# Altium schematic sheet Mirny.schdoc
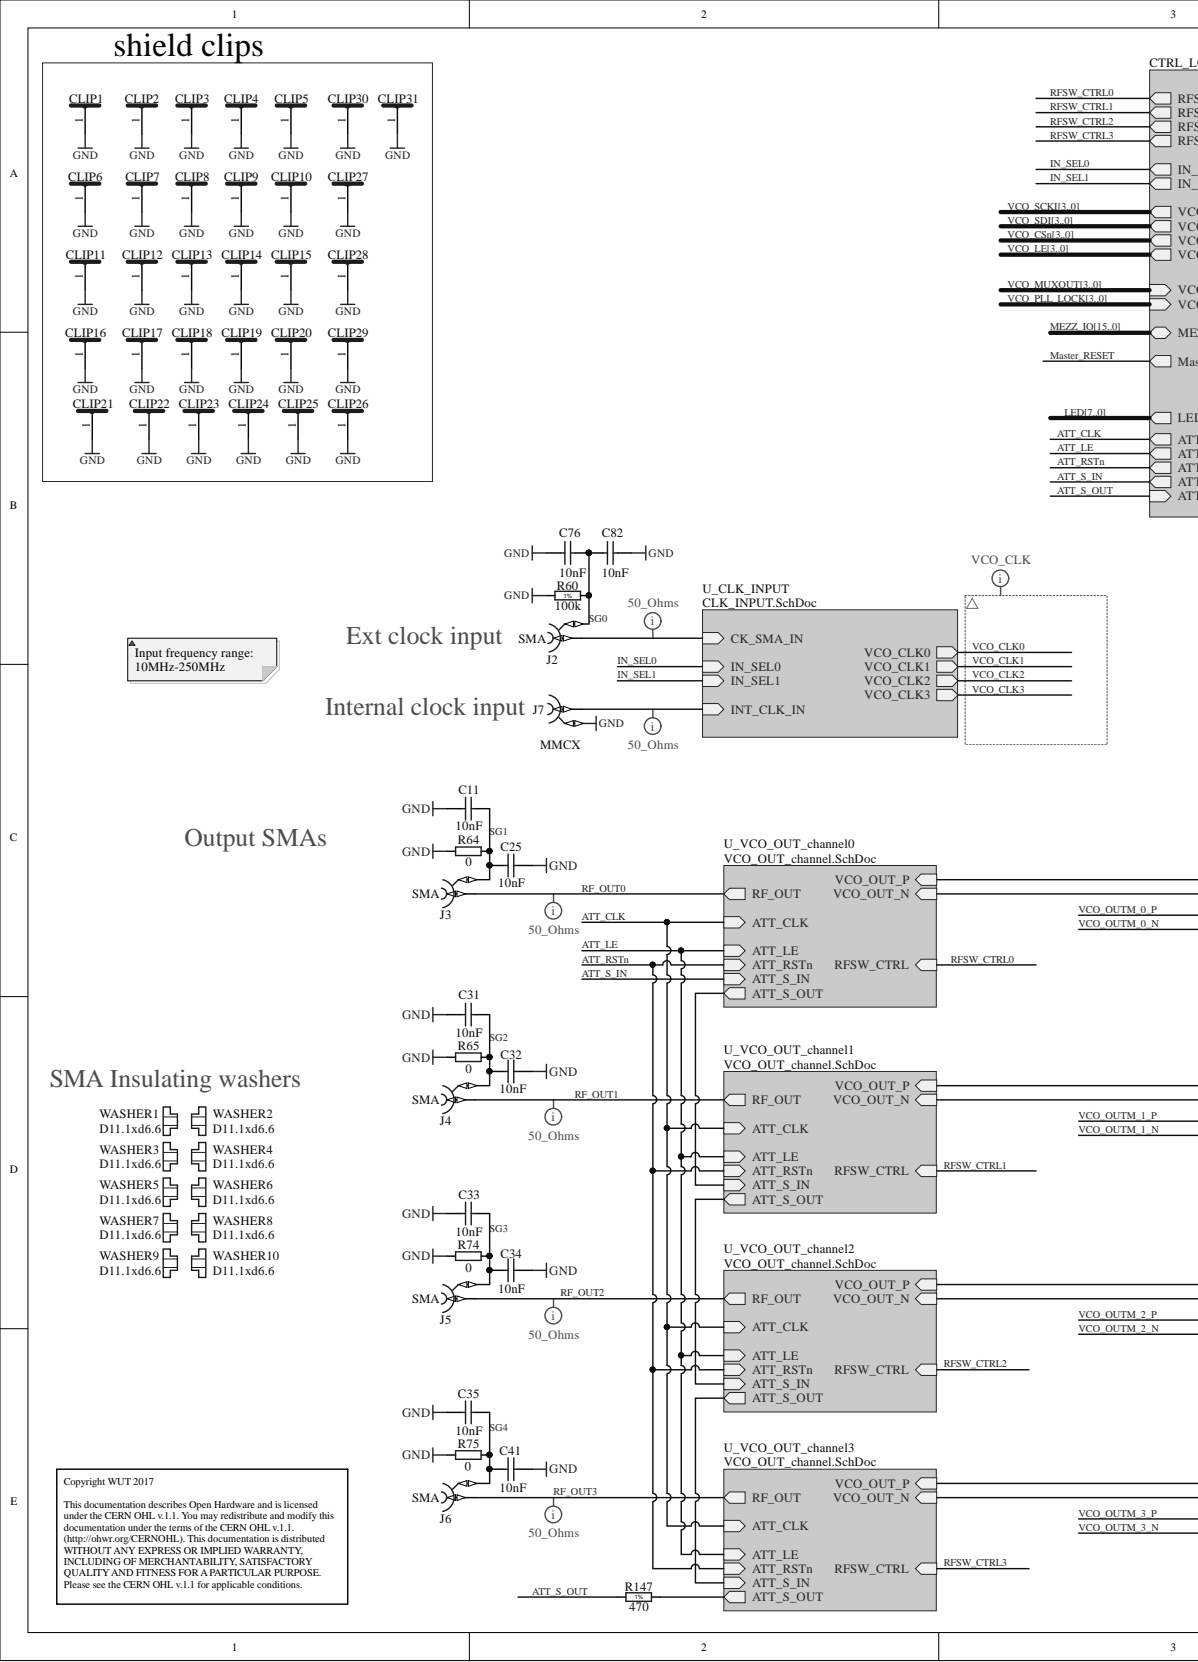
[diagram p0: Mirny.schdoc, left]
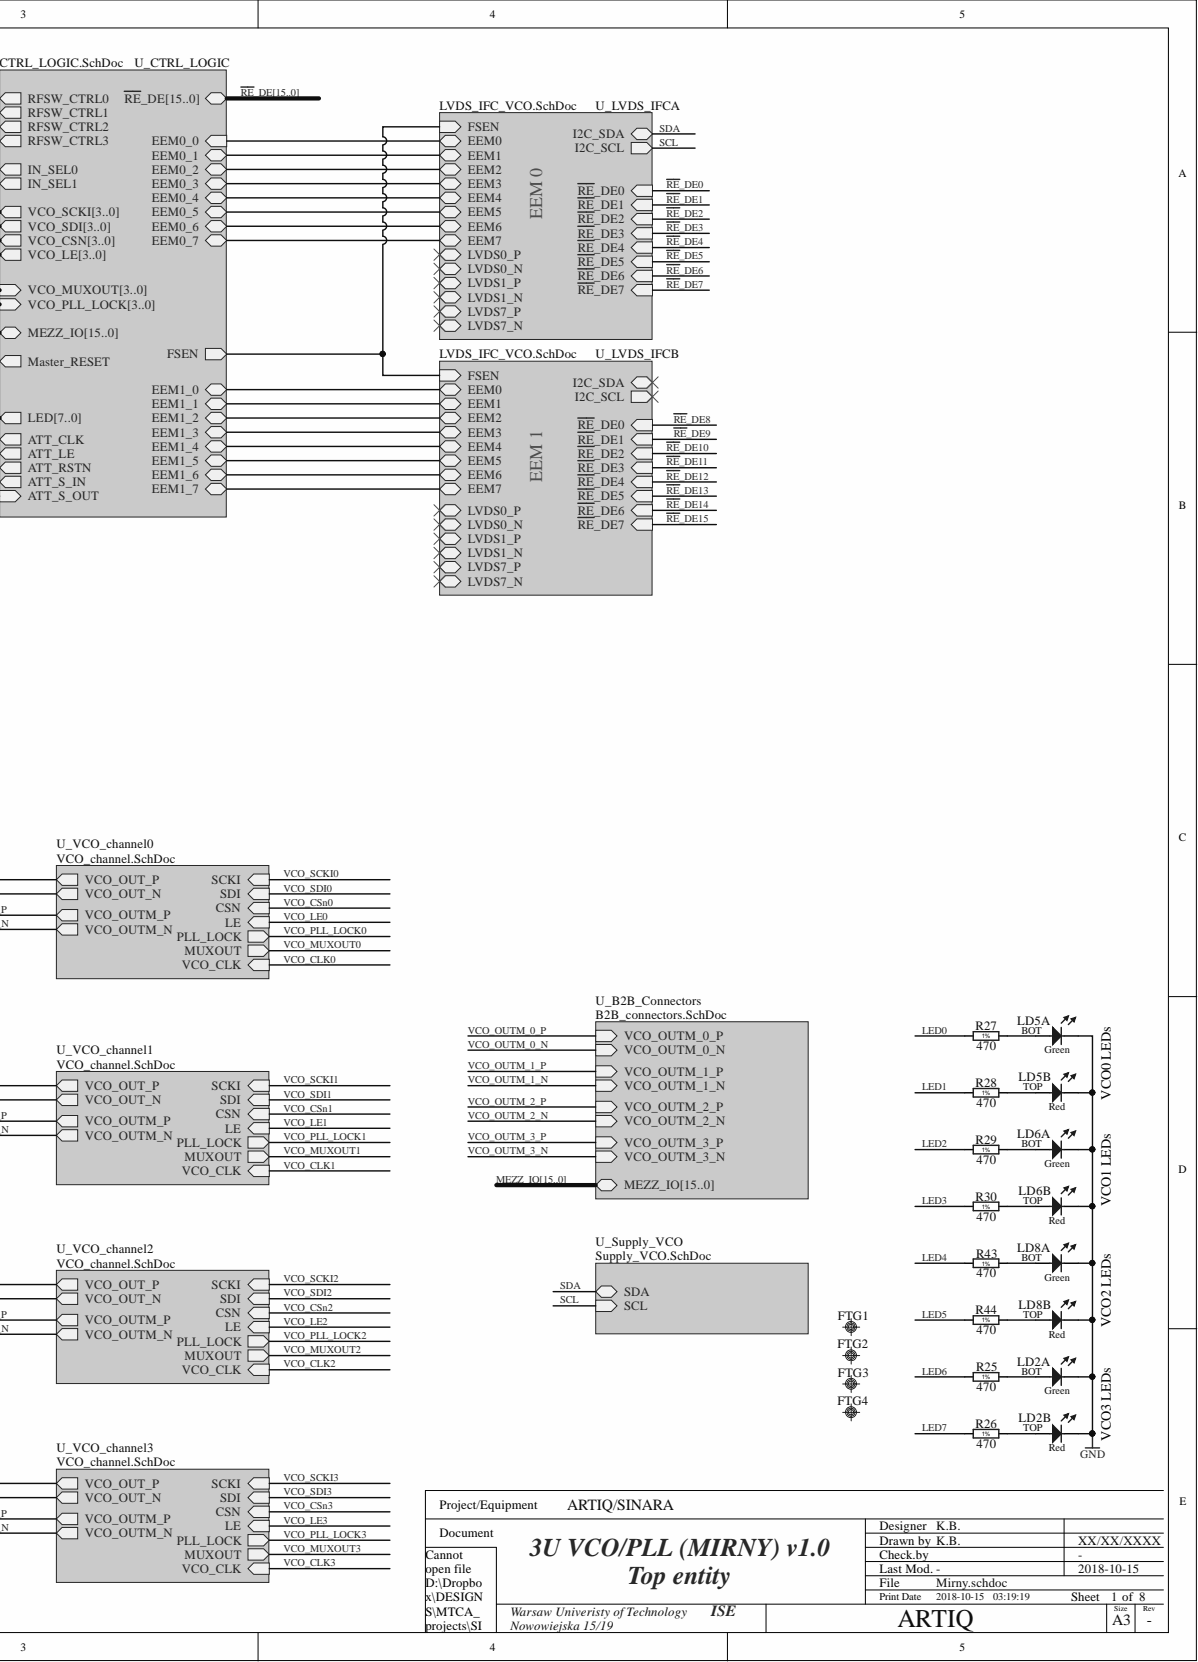
[diagram p1: Mirny.schdoc, right]

## Parts
C11  10nF  [CAPC1005X55N]
C25  10nF  [CAPC1005X55N]
C31  10nF  [CAPC1005X55N]
C32  10nF  [CAPC1005X55N]
C33  10nF  [CAPC1005X55N]
C34  10nF  [CAPC1005X55N]
C35  10nF  [CAPC1005X55N]
C41  10nF  [CAPC1005X55N]
C76  10nF  [CAPC1005X55N]
C82  10nF  [CAPC1005X55N]
CLIP1  S1411-46R  [CLIP_HOLLAND-SHIELDING_LC]  (value not drawn)
CLIP2  S1411-46R  [CLIP_HOLLAND-SHIELDING_LC]  (value not drawn)
CLIP3  S1411-46R  [CLIP_HOLLAND-SHIELDING_LC]  (value not drawn)
CLIP4  S1411-46R  [CLIP_HOLLAND-SHIELDING_LC]  (value not drawn)
CLIP5  S1411-46R  [CLIP_HOLLAND-SHIELDING_LC]  (value not drawn)
CLIP6  S1411-46R  [CLIP_HOLLAND-SHIELDING_LC]  (value not drawn)
CLIP7  S1411-46R  [CLIP_HOLLAND-SHIELDING_LC]  (value not drawn)
CLIP8  S1411-46R  [CLIP_HOLLAND-SHIELDING_LC]  (value not drawn)
CLIP9  S1411-46R  [CLIP_HOLLAND-SHIELDING_LC]  (value not drawn)
CLIP10  S1411-46R  [CLIP_HOLLAND-SHIELDING_LC]  (value not drawn)
CLIP11  S1411-46R  [CLIP_HOLLAND-SHIELDING_LC]  (value not drawn)
CLIP12  S1411-46R  [CLIP_HOLLAND-SHIELDING_LC]  (value not drawn)
CLIP13  S1411-46R  [CLIP_HOLLAND-SHIELDING_LC]  (value not drawn)
CLIP14  S1411-46R  [CLIP_HOLLAND-SHIELDING_LC]  (value not drawn)
CLIP15  S1411-46R  [CLIP_HOLLAND-SHIELDING_LC]  (value not drawn)
CLIP16  S1411-46R  [CLIP_HOLLAND-SHIELDING_LC]  (value not drawn)
CLIP17  S1411-46R  [CLIP_HOLLAND-SHIELDING_LC]  (value not drawn)
CLIP18  S1411-46R  [CLIP_HOLLAND-SHIELDING_LC]  (value not drawn)
CLIP19  S1411-46R  [CLIP_HOLLAND-SHIELDING_LC]  (value not drawn)
CLIP20  S1411-46R  [CLIP_HOLLAND-SHIELDING_LC]  (value not drawn)
CLIP21  S1411-46R  [CLIP_HOLLAND-SHIELDING_LC]  (value not drawn)
CLIP22  S1411-46R  [CLIP_HOLLAND-SHIELDING_LC]  (value not drawn)
CLIP23  S1411-46R  [CLIP_HOLLAND-SHIELDING_LC]  (value not drawn)
CLIP24  S1411-46R  [CLIP_HOLLAND-SHIELDING_LC]  (value not drawn)
CLIP25  S1411-46R  [CLIP_HOLLAND-SHIELDING_LC]  (value not drawn)
CLIP26  S1411-46R  [CLIP_HOLLAND-SHIELDING_LC]  (value not drawn)
CLIP27  S1411-46R  [CLIP_HOLLAND-SHIELDING_LC]  (value not drawn)
CLIP28  S1411-46R  [CLIP_HOLLAND-SHIELDING_LC]  (value not drawn)
CLIP29  S1411-46R  [CLIP_HOLLAND-SHIELDING_LC]  (value not drawn)
CLIP30  S1411-46R  [CLIP_HOLLAND-SHIELDING_LC]  (value not drawn)
CLIP31  S1411-46R  [CLIP_HOLLAND-SHIELDING_LC]  (value not drawn)
FTG1  Use only in PCB  [FIDUCIAL_TOP_C100-200]  (value not drawn)  (no BOM)
FTG2  Use only in PCB  [FIDUCIAL_TOP_S100-200]  (value not drawn)  (no BOM)
FTG3  Use only in PCB  [FIDUCIAL_TOP_S200-400]  (value not drawn)  (no BOM)
FTG4  Use only in PCB  [FIDUCIAL_TOP_S100-200]  (value not drawn)  (no BOM)
J2  SMA  [RF249BT0050GHDW]
J3  SMA  [RF249BT0050GHDW]
J4  SMA  [RF249BT0050GHDW]
J5  SMA  [RF249BT0050GHDW]
J6  SMA  [RF249BT0050GHDW]
J7  MMCX  [SAMTEC_MMCX-J-P-H-ST-TH1]
LD2  Red/Green  [LED_DIALIGHT_553-0112-200F]  (value not drawn)  (parts A,B)
LD5  Red/Green  [LED_DIALIGHT_553-0112-200F]  (value not drawn)  (parts A,B)
LD6  Red/Green  [LED_DIALIGHT_553-0112-200F]  (value not drawn)  (parts A,B)
LD8  Red/Green  [LED_DIALIGHT_553-0112-200F]  (value not drawn)  (parts A,B)
R25  470  [RESC1005X40N]
R26  470  [RESC1005X40N]
R27  470  [RESC1005X40N]
R28  470  [RESC1005X40N]
R29  470  [RESC1005X40N]
R30  470  [RESC1005X40N]
R43  470  [RESC1005X40N]
R44  470  [RESC1005X40N]
R60  100k  [RESC1005X40N]
R64  0  [RESC1005X40N]
R65  0  [RESC1005X40N]
R74  0  [RESC1005X40N]
R75  0  [RESC1005X40N]
R147  470  [RESC1005X40N]
WASHER1  D11.1xd6.6  [Keystone_7687]
WASHER2  D11.1xd6.6  [Keystone_7687]
WASHER3  D11.1xd6.6  [Keystone_7687]
WASHER4  D11.1xd6.6  [Keystone_7687]
WASHER5  D11.1xd6.6  [Keystone_7687]
WASHER6  D11.1xd6.6  [Keystone_7687]
WASHER7  D11.1xd6.6  [Keystone_7687]
WASHER8  D11.1xd6.6  [Keystone_7687]
WASHER9  D11.1xd6.6  [Keystone_7687]
WASHER10  D11.1xd6.6  [Keystone_7687]

## Nets
GND: C11.2 C25.1 C31.2 C32.1 C33.2 C34.1 C35.2 C41.1 C76.1 C82.2 CLIP1.1 CLIP2.1 CLIP3.1 CLIP4.1 CLIP5.1 CLIP6.1 CLIP7.1 CLIP8.1 CLIP9.1 CLIP10.1 CLIP11.1 CLIP12.1 CLIP13.1 CLIP14.1 CLIP15.1 CLIP16.1 CLIP17.1 CLIP18.1 CLIP19.1 CLIP20.1 CLIP21.1 CLIP22.1 CLIP23.1 CLIP24.1 CLIP25.1 CLIP26.1 CLIP27.1 CLIP28.1 CLIP29.1 CLIP30.1 CLIP31.1 J7.2 LD2.1 LD2.3(TOP) LD5.1 LD5.3 LD6.1 LD6.3 LD8.1 LD8.3 R60.2 R64.1 R65.1 R74.1 R75.1
ATT_S_OUT: R147.1
LED0: R27.1  [also labelled LED]
LED1: R28.1  [also labelled LED]
LED2: R29.1  [also labelled LED]
LED3: R30.1  [also labelled LED]
LED4: R43.1  [also labelled LED]
LED5: R44.1  [also labelled LED]
LED6: R25.1  [also labelled LED]
LED7: R26.1  [also labelled LED]
RF_OUT0: J3.1
RF_OUT1: J4.1
RF_OUT2: J5.1
RF_OUT3: J6.1
SG0: C76.2 C82.1 J2.2 R60.1
SG1: C11.1 C25.2 J3.2 R64.2
SG2: C31.1 C32.2 J4.2 R65.2
SG3: C33.1 C34.2 J5.2 R74.2
SG4: C35.1 C41.2 J6.2 R75.2
NetJ2_1: J2.1
NetJ7_1: J7.1
NetLD2_2: LD2.2 R25.2
NetLD2_4: LD2.4 R26.2
NetLD5_2: LD5.2 R27.2
NetLD5_4: LD5.4 R28.2
NetLD6_2: LD6.2 R29.2
NetLD6_4: LD6.4 R30.2
NetLD8_2: LD8.2 R43.2
NetLD8_4: LD8.4 R44.2
NetR147_2: R147.2
ATT_CLK:
ATT_LE:
ATT_RSTn:
ATT_S_IN:
EEM0_0:
EEM0_1:
EEM0_2:
EEM0_3:
EEM0_4:
EEM0_5:
EEM0_6:
EEM0_7:
EEM1_0:
EEM1_1:
EEM1_2:
EEM1_3:
EEM1_4:
EEM1_5:
EEM1_6:
EEM1_7:
FSEN:
I2C_SCL:
I2C_SDA:
IN_SEL0:
IN_SEL1:
LVDS0_N:
LVDS0_P:
LVDS1_N:
LVDS1_P:
LVDS7_N:
LVDS7_P:
Master_RESET:
MEZZ_IO0:  [also labelled MEZZ_IO]
MEZZ_IO1:  [also labelled MEZZ_IO]
MEZZ_IO2:  [also labelled MEZZ_IO]
MEZZ_IO3:  [also labelled MEZZ_IO]
MEZZ_IO4:  [also labelled MEZZ_IO]
MEZZ_IO5:  [also labelled MEZZ_IO]
MEZZ_IO6:  [also labelled MEZZ_IO]
MEZZ_IO7:  [also labelled MEZZ_IO]
MEZZ_IO8:  [also labelled MEZZ_IO]
MEZZ_IO9:  [also labelled MEZZ_IO]
MEZZ_IO10:  [also labelled MEZZ_IO]
MEZZ_IO11:  [also labelled MEZZ_IO]
MEZZ_IO12:  [also labelled MEZZ_IO]
MEZZ_IO13:  [also labelled MEZZ_IO]
MEZZ_IO14:  [also labelled MEZZ_IO]
MEZZ_IO15:  [also labelled MEZZ_IO]
N00185:
~{RE}_DE0:  [also labelled ~{RE}_DE]
~{RE}_DE1:  [also labelled ~{RE}_DE]
~{RE}_DE2:  [also labelled ~{RE}_DE]
~{RE}_DE3:  [also labelled ~{RE}_DE]
~{RE}_DE4:  [also labelled ~{RE}_DE]
~{RE}_DE5:  [also labelled ~{RE}_DE]
~{RE}_DE6:  [also labelled ~{RE}_DE]
~{RE}_DE7:  [also labelled ~{RE}_DE]
~{RE}_DE8:  [also labelled ~{RE}_DE]
~{RE}_DE9:  [also labelled ~{RE}_DE]
~{RE}_DE10:  [also labelled ~{RE}_DE]
~{RE}_DE11:  [also labelled ~{RE}_DE]
~{RE}_DE12:  [also labelled ~{RE}_DE]
~{RE}_DE13:  [also labelled ~{RE}_DE]
~{RE}_DE14:  [also labelled ~{RE}_DE]
~{RE}_DE15:  [also labelled ~{RE}_DE]
RFSW_CTRL0:
RFSW_CTRL1:
RFSW_CTRL2:
RFSW_CTRL3:
SCL:
SDA:
VCO_CLK0:
VCO_CLK1:
VCO_CLK2:
VCO_CLK3:
VCO_CSn0:  [also labelled VCO_CSN]
VCO_CSn1:  [also labelled VCO_CSN]
VCO_CSn2:  [also labelled VCO_CSN]
VCO_CSn3:  [also labelled VCO_CSN]
VCO_LE0:  [also labelled VCO_LE]
VCO_LE1:  [also labelled VCO_LE]
VCO_LE2:  [also labelled VCO_LE]
VCO_LE3:  [also labelled VCO_LE]
VCO_MUXOUT0:  [also labelled VCO_MUXOUT]
VCO_MUXOUT1:  [also labelled VCO_MUXOUT]
VCO_MUXOUT2:  [also labelled VCO_MUXOUT]
VCO_MUXOUT3:  [also labelled VCO_MUXOUT]
VCO_OUT_N:
VCO_OUT_P:
VCO_OUTM_0_N:
VCO_OUTM_0_P:
VCO_OUTM_1_N:
VCO_OUTM_1_P:
VCO_OUTM_2_N:
VCO_OUTM_2_P:
VCO_OUTM_3_N:
VCO_OUTM_3_P:
VCO_PLL_LOCK0:  [also labelled VCO_PLL_LOCK]
VCO_PLL_LOCK1:  [also labelled VCO_PLL_LOCK]
VCO_PLL_LOCK2:  [also labelled VCO_PLL_LOCK]
VCO_PLL_LOCK3:  [also labelled VCO_PLL_LOCK]
VCO_SCKI0:  [also labelled VCO_SCKI]
VCO_SCKI1:  [also labelled VCO_SCKI]
VCO_SCKI2:  [also labelled VCO_SCKI]
VCO_SCKI3:  [also labelled VCO_SCKI]
VCO_SDI0:  [also labelled VCO_SDI]
VCO_SDI1:  [also labelled VCO_SDI]
VCO_SDI2:  [also labelled VCO_SDI]
VCO_SDI3:  [also labelled VCO_SDI]

## Library data of the parts
C11 C25 C31-C35 C41 C76 C82 = CC0402_10NF_16V_10%_X7R: Non-polarised capacitor; Manufacturer: GENERIC; Manufacturer1 Part Number: 223878715636; Part Description: SMD Multilayer Chip Ceramic Capacitor; Database Table Name: Capacitors SMD; TC: X7R; Tolerance: ±10%; Voltage: 16V; Case: 0402; Component Kind: Standard; Component Type: Standard; CreateDate: 2007-12-03; HelpURL: \\cern.ch\dfs\Applications\Altium\Datasheets\CC0402_X7R_PHYCOMP.pdf; LatestRevisionDate: 2007-12-03; Library Name: Capacitors.DbLib; Manufacturer1 ComponentHeight: 0.55mm; Manufacturer1 Example: PHYCOMP; Manufacturer Part Number: CC0402_10NF_16V_10%_X7R; Mounted: Yes; Part Number: CC0402_10NF_16V_10%_X7R; Pin Count: 2; Sense: No; SMD: Yes; Socket: No; Status: Not Recommended; Value: 10nF; Author: CERN DEM JLC
CLIP1-CLIP31 = (no symbol name): Surface Mount Clip for PCB Screening Covers; Manufacturer: HARWIN; Part Description: Surface Mount Clip for PCB Screening Covers, 1500 Serie; PressFit: No; Table: Metal Screening Box; ComponentHeight: 3.5mm; Component Kind: Standard; Component Type: Standard; CreateDate: 2013-06-25; HelpURL: \\cern.ch\dfs\Applications\Altium\Datasheets\CLIP_HOLLAND-SHIELDING_LC.pdf; LatestRevisionDate: 2013-06-25; Library Name: LIBRARY_SCH.SCHLIB; Manufacturer Part Number: S1411-46R; Mounted: Yes; PackageDescription: Surface Mount Clip for PCB Screening Covers, 1500 Serie; Part Number: S1411-46R; Pin Count: 1; SMD: Yes; Socket: No; Status: None; Author: CERN DEM JLC
FTG1 = FIDUCIAL_TARGET_C100-200: Fiducial Target; Part Description: Round Fiducial Target, Top Layer, 1.0mm, Top Solder 2.0mm, Keepout =2.0mm; Database Table Name: Pads; Mounted: No; ComponentHeight: 0mm; Component Type: Standard (No BOM); CreateDate: 09.02.2010; Family: Fiducial; HelpURL: Undefined; LatestRevisionDate: 04.04.2012; Library Name: Eletro-mechanical.DbLib; PackageDescription: Round Fiducial Target, Top Layer, 1.0mm, Top Solder 2.0mm, Keepout =2.0mm; Part Number: FIDUCIAL_TARGET_C100-200; Pin Count: 1; Sense: No; SMD: Yes; Socket: No; Status: None; Author: CERN DEM JLC
FTG2 FTG4 = FIDUCIAL_TARGET_S100-200: Fiducial Target; Part Description: Square Fiducial Target, Top Layer, 1.0mm, Top Solder 2.0mm, Keepout =2.0mm; Database Table Name: Pads; Mounted: No; ComponentHeight: 0mm; Component Type: Standard (No BOM); CreateDate: 09.02.2010; Family: Fiducial; HelpURL: Undefined; LatestRevisionDate: 04.04.2012; Library Name: Eletro-mechanical.DbLib; PackageDescription: Square Fiducial Target, Top Layer, 1.0mm, Top Solder 2.0mm, Keepout =2.0mm; Part Number: FIDUCIAL_TARGET_S100-200; Pin Count: 1; Sense: No; SMD: Yes; Socket: No; Status: None; Author: CERN DEM JLC
FTG3 = FIDUCIAL_TARGET_S200-400: Fiducial Target; Part Description: Square Fiducial Target, Top Layer, 2.0mm, Top Solder 4.0mm, Keepout =4.0mm; Database Table Name: Pads; Mounted: No; ComponentHeight: 0mm; Component Type: Standard (No BOM); CreateDate: 02.06.2008; Family: Fiducial; HelpURL: Undefined; LatestRevisionDate: 04.04.2012; Library Name: Eletro-mechanical.DbLib; PackageDescription: Square Fiducial Target, Top Layer, 2.0mm, Top Solder 4.0mm, Keepout =4.0mm; Part Number: FIDUCIAL_TARGET_S200-400; Pin Count: 1; Sense: No; SMD: Yes; Socket: No; Status: None; Author: CERN DEM JLC
J2-J6 = (no symbol name): 50 Ohm Through Hole SMA Right Angle PCB Jack; Manufacturer: AdamTech; Part Number: AdamTech_RF249BT0050GHDW; PressFit: No; ComponentHeight: 10.44mm; Component Kind: Standard; Component Type: Standard; CreateDate: 06.10.2016; Family: SMA; HelpURL: \\cern.ch\dfs\Applications\Altium\Datasheets\AMPHENOL_132203.pdf; LatestRevisionDate: 06.10.2016; Library Name: LIBRARY_SCH.SCHLIB; Manufacturer Part Number: RF249BT0050GHDW; Mounted: Yes; Part Description: 50 Ohm Through Hole SMA Right Angle PCB Jack; Pin Count: 5; Sense: No; SMD: No; Socket: No; Status: None; Author: GK
J7 = SAMTEC_MMCX-J-P-H-ST-TH1: Part Description: Straight Through-hole 50 Ohm Micro Mini RF Jack, MMCX Series; Database Table Name: SAMTEC; PressFit: No; ComponentHeight: 4.35mm; Component Kind: Standard; Component Type: Standard; CreateDate: 05.12.2012; HelpURL: \\cern.ch\dfs\Applications\Altium\Datasheets\SAMTEC_MMCX-J-P-H-ST-TH1.pdf; LatestRevisionDate: 05.12.2012; Library Name: Connectors.DbLib; Manufacturer: SAMTEC; Manufacturer Part Number: MMCX-J-P-H-ST-TH1; Mounted: Yes; Part Number: SAMTEC_MMCX-J-P-H-ST-TH1; Pin Count: 2; Sense: No; SMD: No; Socket: No; Status: None; Author: CERN DEM JLC
LD2 LD5 LD6 LD8 = LED_DIALIGHT_553-0112-200F: 2x LED  Botom Green, Top Red; Part Description: Dual 3mm LEDs, Red Top, Green Bot, CBI® Circuit Board Indicator; Bonding: No; Database Table Name: LEDs & Displays; PressFit: No; Color: Red/Green; ComponentHeight: 9.65mm; Component Kind: Standard; Component Type: Standard; CreateDate: 07.06.2013; HelpURL: \\cern.ch\dfs\Applications\Altium\Datasheets\LED_DIALIGHT_553-0112-200F.pdf; LatestRevisionDate: 07.06.2013; Library Name: ICs And Semiconductors.DbLib; Manufacturer: DIALIGHT; Manufacturer Part Number: 553-0112-200F; Mounted: Yes; PackageDescription: Dual 3mm LED  (Top: Red, Bot: Green), Body 10.55x4.32mm, Pin spacing 2.54mm; Part Number: LED_DIALIGHT_553-0112-200F; Pin Count: 4; Sense: No; SMD: No; Socket: No; Author: CERN DEM JMW
R25-R30 R43 R44 R147 = R0402_470R_1%_0.0625W_100PPM: Resistor - 1%; Manufacturer: GENERIC; Manufacturer1 Part Number: 232270674701L; Part Description: General Purpose Thick Film Chip Resistor; Database Table Name: Resistors SMD; PressFit: No; TC: ±100ppm/°C; Case: 0402; Component Kind: Standard; Component Type: Standard; CreateDate: 03.12.2007; HelpURL: \\cern.ch\dfs\Applications\Altium\Datasheets\R0402_Phycomp_RC0402.pdf; LatestRevisionDate: 17.10.2012; Library Name: Resistors.DbLib; Manufacturer1 ComponentHeight: 0.4mm; Manufacturer1 Example: YAGEO PHYCOMP; Manufacturer Part Number: R0402_470R_1%_0.0625W_100PPM; Mounted: Yes; Part Number: R0402_470R_1%_0.0625W_100PPM; Pin Count: 2; Power: 0.0625W; Sense: No; SMD: Yes; Socket: No; Status: Not Recommended; Tolerance: ±1%; Value: 470; Author: CERN DEM JLC
R60 = R0402_100K_1%_0.0625W_100PPM: Resistor - 1%; Manufacturer: GENERIC; Manufacturer1 Part Number: 232270671004L; Part Description: General Purpose Thick Film Chip Resistor; Database Table Name: Resistors SMD; PressFit: No; TC: ±100ppm/°C; Case: 0402; Component Kind: Standard; Component Type: Standard; CreateDate: 03.12.2007; HelpURL: \\cern.ch\dfs\Applications\Altium\Datasheets\R0402_Phycomp_RC0402.pdf; LatestRevisionDate: 17.10.2012; Library Name: Resistors.DbLib; Manufacturer1 ComponentHeight: 0.4mm; Manufacturer1 Example: YAGEO PHYCOMP; Manufacturer Part Number: R0402_100K_1%_0.0625W_100PPM; Mounted: Yes; Part Number: R0402_100K_1%_0.0625W_100PPM; Pin Count: 2; Power: 0.0625W; Sense: No; SMD: Yes; Socket: No; Status: Not Recommended; Tolerance: ±1%; Value: 100k; Author: CERN DEM JLC
R64 R65 R74 R75 = R0402_0R_JUMPER: Resistor; Manufacturer: GENERIC; Manufacturer1 Part Number: 232270591001L; Part Description: 1A (0.05R Max DC Resistance) Zero Ohm Jumper; Database Table Name: Resistors SMD; PressFit: No; Case: 0402; Component Kind: Standard; Component Type: Standard; CreateDate: 03.12.2007; HelpURL: \\cern.ch\dfs\Applications\Altium\Datasheets\R0402_Phycomp_RC0402.pdf; LatestRevisionDate: 17.10.2012; Library Name: Resistors.DbLib; Manufacturer1 ComponentHeight: 0.4mm; Manufacturer1 Example: YAGEO PHYCOMP; Manufacturer Part Number: R0402_0R_JUMPER; Mounted: Yes; Part Number: R0402_0R_JUMPER; Pin Count: 2; Sense: No; SMD: Yes; Socket: No; Status: Not Recommended; Value: 0; Author: CERN DEM JLC
WASHER1-WASHER10 = (no symbol name): SMA_Shoulder Washer; Manufacturer: Keystone; Manufacturer Part Number: 7687; Part Description: Polyphenylene Sulfide PPS Shoulder Washer; PressFit: No; ComponentHeight: 1.91mm; Component Kind: Standard; Component Type: Mechanical; CreateDate: 28.01.2016; HelpURL: \\cern.ch\dfs\Applications\Altium\Datasheets\AAVID-THERMALLOY_7721-7PPSG.pdf; LatestRevisionDate: 28.01.2016; Library Name: LIBRARY_SCH.SCHLIB; Mounted: Yes; PackageDescription: Polyphenylene Sulfide PPS Shoulder Washer; Part Number: Keystone 7687; Pin Count: 0; Sense: No; SMD: No; Socket: No; Status: None; Value: D11.1xd6.6; Author: GK
